# Altium schematic sheet [SCH]_IO.SchDoc
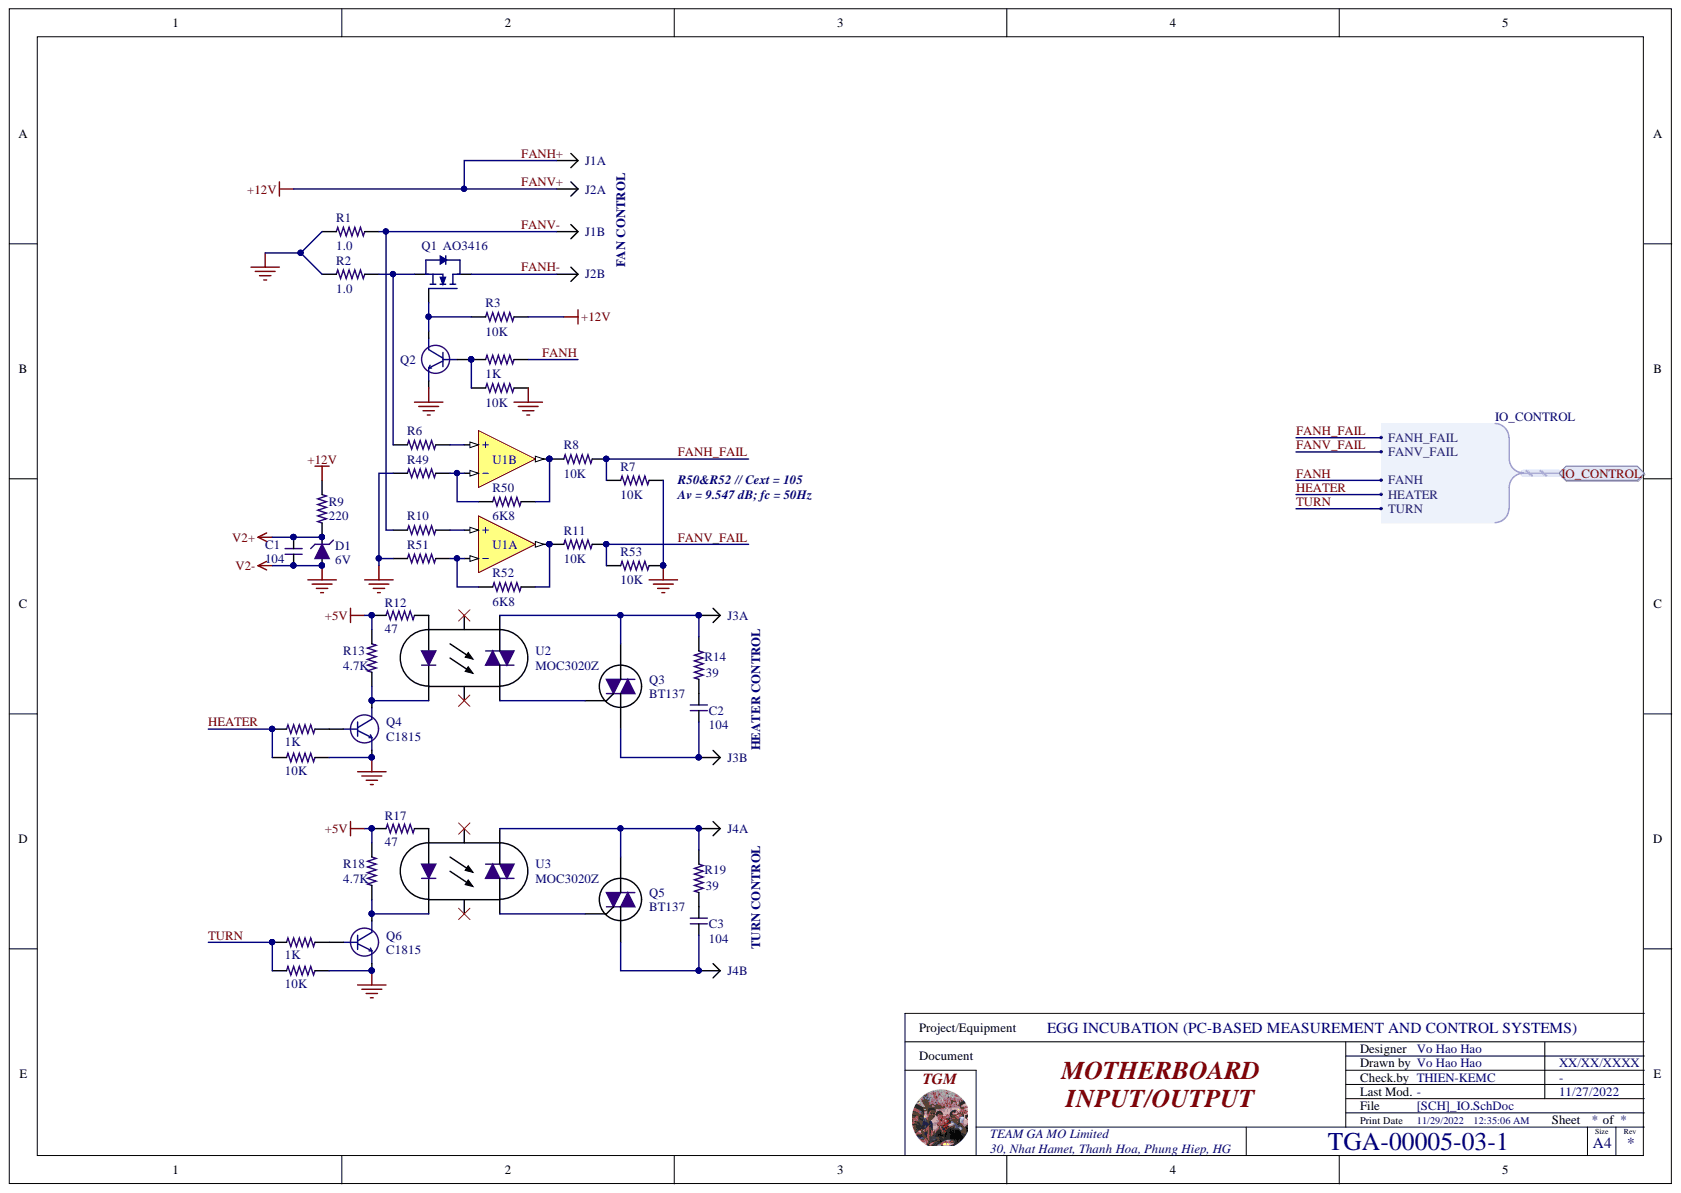
[diagram p0: [SCH]_IO.SchDoc]

## Parts
C1  104  [CAP-SMD-0805]
C2  104  [Cap-Mica-10-6.2x12.5x10.5]
C3  104  [Cap-Mica-10-6.2x12.5x10.5]
D1  6V  [DIO-SMD-123]
J1  FAN-HUMI  [KF2/5.08]  (value not drawn)  (parts A,B)
J2  FAN-VENT  [KF2/5.08]  (value not drawn)  (parts A,B)
J3  HEATER  [KF2/5.08]  (value not drawn)  (parts A,B)
J4  TURN  [KF2/5.08]  (value not drawn)  (parts A,B)
Q1  AO3416  [SOT23(123)]
Q2  NPN  [SOT23(123)]  (value not drawn)
Q3  BT137  [TO252AA]
Q4  C1815  [SOT23(123)]
Q5  BT137  [TO252AA]
Q6  C1815  [SOT23(123)]
R1  value 1.0  [RES-SMD-2512]  (hidden comment: RES-2512-4.7R)
R2  value 1.0  [RES-SMD-2512]  (hidden comment: RES-2512-4.7R)
R3  value 10K  [RES-SMD-0805]  (hidden comment: RES-2512-4.7R)
R4  value 1K  [RES-SMD-0805]  (hidden comment: RES-2512-4.7R)
R5  value 10K  [RES-SMD-0805]  (hidden comment: RES-2512-4.7R)
R6  RES-2512-4.7R  [RES-SMD-0805]  (value not drawn)
R7  value 10K  [RES-SMD-0805]  (hidden comment: RES-2512-4.7R)
R8  value 10K  [RES-SMD-0805]  (hidden comment: RES-2512-4.7R)
R9  value 220  [RES-SMD-0805]  (hidden comment: R0805)
R10  RES-2512-4.7R  [RES-SMD-0805]  (value not drawn)
R11  value 10K  [RES-SMD-0805]  (hidden comment: RES-2512-4.7R)
R12  value 47  [RES-SMD-0805]  (hidden comment: RES-2512-4.7R)
R13  value 4.7K  [RES-SMD-0805]  (hidden comment: RES-2512-4.7R)
R14  value 39  [RES-SMD-2512]  (hidden comment: RES)
R15  value 1K  [RES-SMD-0805]  (hidden comment: RES-2512-4.7R)
R16  value 10K  [RES-SMD-0805]  (hidden comment: RES-2512-4.7R)
R17  value 47  [RES-SMD-0805]  (hidden comment: RES-2512-4.7R)
R18  value 4.7K  [RES-SMD-0805]  (hidden comment: RES-2512-4.7R)
R19  value 39  [RES-SMD-2512]  (hidden comment: RES)
R20  value 1K  [RES-SMD-0805]  (hidden comment: RES-2512-4.7R)
R21  value 10K  [RES-SMD-0805]  (hidden comment: RES-2512-4.7R)
R49  RES-2512-4.7R  [RES-SMD-0805]  (value not drawn)
R50  value 6K8  [RES-SMD-0805]  (hidden comment: RES-2512-4.7R)
R51  RES-2512-4.7R  [RES-SMD-0805]  (value not drawn)
R52  value 6K8  [RES-SMD-0805]  (hidden comment: RES-2512-4.7R)
R53  value 10K  [RES-SMD-0805]  (hidden comment: RES-2512-4.7R)
U1  LM358  [SOIC-8S]  (value not drawn)  (parts A,B)
U2  MOC3020Z  [DIP6]
U3  MOC3020Z  [DIP6]

## Ports
IO_CONTROL, IO_CONTROL.FANH, IO_CONTROL.FANH_FAIL, IO_CONTROL.FANV_FAIL, IO_CONTROL.HEATER, IO_CONTROL.TURN

## Nets
+5V: R12.2 R13.1 R17.2 R18.1
GND: C1.1 D1.1 Q2.3 Q4.3 Q6.3 R1.1 R2.1 R5.2 R7.2 R16.2 R21.2 R49.1 R51.1 R53.2 U1.4
V2+: C1.2 D1.2 R9.1 U1.8
FANH: R4.2 ; port IO_CONTROL
FANH+: J1.1 J2.1 R3.2 R9.2
FANH-: J2.2 Q1.2
FANH_FAIL: R7.1 R8.2 ; port IO_CONTROL
FANV-: J1.2 R1.2 R10.1
FANV_FAIL: R11.2 R53.1 ; port IO_CONTROL
HEATER: R15.1 R16.1 ; port IO_CONTROL
TURN: R20.1 R21.1 ; port IO_CONTROL
NetC2_1: C2.1 J3.2 Q3.1
NetC2_2: C2.2 R14.1
NetC3_1: C3.1 J4.2 Q5.1
NetC3_2: C3.2 R19.1
NetJ3_1: J3.1 Q3.2 R14.2 U2.6
NetJ4_1: J4.1 Q5.2 R19.2 U3.6
NetQ1_1: Q1.1 Q2.2 R3.1
NetQ1_3: Q1.3 R2.2 R6.1
NetQ2_1: Q2.1 R4.1 R5.1
NetQ3_3: Q3.3 U2.4
NetQ4_1: Q4.1 R15.2
NetQ4_2: Q4.2 R13.2 U2.2
NetQ5_3: Q5.3 U3.4
NetQ6_1: Q6.1 R20.2
NetQ6_2: Q6.2 R18.2 U3.2
NetR6_2: R6.2 U1.5
NetR8_1: R8.1 R50.2 U1.7
NetR10_2: R10.2 U1.3
NetR11_1: R11.1 R52.2 U1.1
NetR12_1: R12.1 U2.1
NetR17_1: R17.1 U3.1
NetR49_2: R49.2 R50.1 U1.6
NetR51_2: R51.2 R52.1 U1.2

Not connected: U2 3 5; U3 3 5

## Library data of the parts
C1 = Cap-Non-SMD: Non-polar capacitor; Component Kind: Standard; Library Name: TOAN_VERSION_1_0.IntLib
C2 C3 = Cap-Non-DIP: Non-polar capacitor; Component Kind: Standard; Price: 0.005; Library Name: TOAN_VERSION_1_0.IntLib
D1 = ZENER: DIODE-ZNR 5.1V 5% PD=1W IR=10uA; Manufacturer: Littlefuse Inc.; Manufacturer’s ordering code: SMF22A; Value: 24.4V; Component Kind: Standard; Part Number: SMF22A; Supplier 1: Digi-Key; Supplier 2: Mouser; Supplier 3: LCSC; Supplier Part Number 1: F5822CT-ND; Supplier Part Number 2: 576-SMF22A; Supplier Part Number 3: C169431; Library Name: TOAN_VERSION_1_0.IntLib
J1-J4 = JP2: Component Kind: Standard; Library Name: TOAN_VERSION_1_0.IntLib
Q1 = IRFR3910TRLPBF: N-Channel 16A (Tc) 79W (Tc) Surface Mount D-Pak; Component Kind: Standard; Price: 0.15; Supplier 1: Digi-Key; Supplier 2: Mouser; Supplier 3: LCSC; Supplier Part Number 1: IRFR3910TRLPBFCT-ND; Supplier Part Number 2: 942-IRFR3910TRLPBF; Supplier Part Number 3: C148158; Library Name: TOAN_VERSION_1_0.IntLib
Q2 Q4 Q6 = NPN: Transistor, NPN, RF, 50mA, 12V; Component Kind: Standard; Supplier 1: Digi-Key; Supplier Part Number 1: 568-11514-1-ND; Library Name: TOAN_VERSION_1_0.IntLib
Q3 Q5 = SCR: THYRISTOR-TRIAC TO-220AB VRRM=600; Component Kind: Standard; Library Name: TOAN_VERSION_1_0.IntLib
R1 = RES: Chip Resistor, 0805, 1%, 1/8 W; Value: 1.0; Component Kind: Standard; PackageDescription: Chip Resistor; PackageReference: J1-0805; Price: 0.005; Simulation: RESISTOR; Supplier Part Number 1: 311-1.00KLRCT-ND; Library Name: TOAN_VERSION_1_0.IntLib
R2 R4 R5 R7-R9 R11-R21 R50 R52 R53 = RES: Chip Resistor, 0805, 1%, 1/8 W; Component Kind: Standard; PackageDescription: Chip Resistor; PackageReference: J1-0805; Price: 0.005; Simulation: RESISTOR; Supplier Part Number 1: 311-1.00KLRCT-ND; Library Name: TOAN_VERSION_1_0.IntLib
R3 = RES: Chip Resistor, 0805, 1%, 1/8 W; Value: 10K; Component Kind: Standard; PackageDescription: Chip Resistor; PackageReference: J1-0805; Price: 0.005; Simulation: RESISTOR; Supplier Part Number 1: 311-1.00KLRCT-ND; Library Name: TOAN_VERSION_1_0.IntLib
R6 R10 R49 R51 = RES: Chip Resistor, 0805, 1%, 1/8 W; Value: 1K; Component Kind: Standard; PackageDescription: Chip Resistor; PackageReference: J1-0805; Price: 0.005; Simulation: RESISTOR; Supplier Part Number 1: 311-1.00KLRCT-ND; Library Name: TOAN_VERSION_1_0.IntLib
U1 = LM358: 7mV 250nA 7uV/C 1MHz 0.6V/uS 0.8mA 3-32V; Component Kind: Standard; Price: 0.24; Library Name: TOAN_VERSION_1_0.IntLib
U2 U3 = MOC3020Z: OPTO-ISOLATOR LED-PTRIAC IF=50mA-max; Component Kind: Standard; Library Name: TOAN_VERSION_1_0.IntLib
